# Altium schematic sheet board.SchDoc
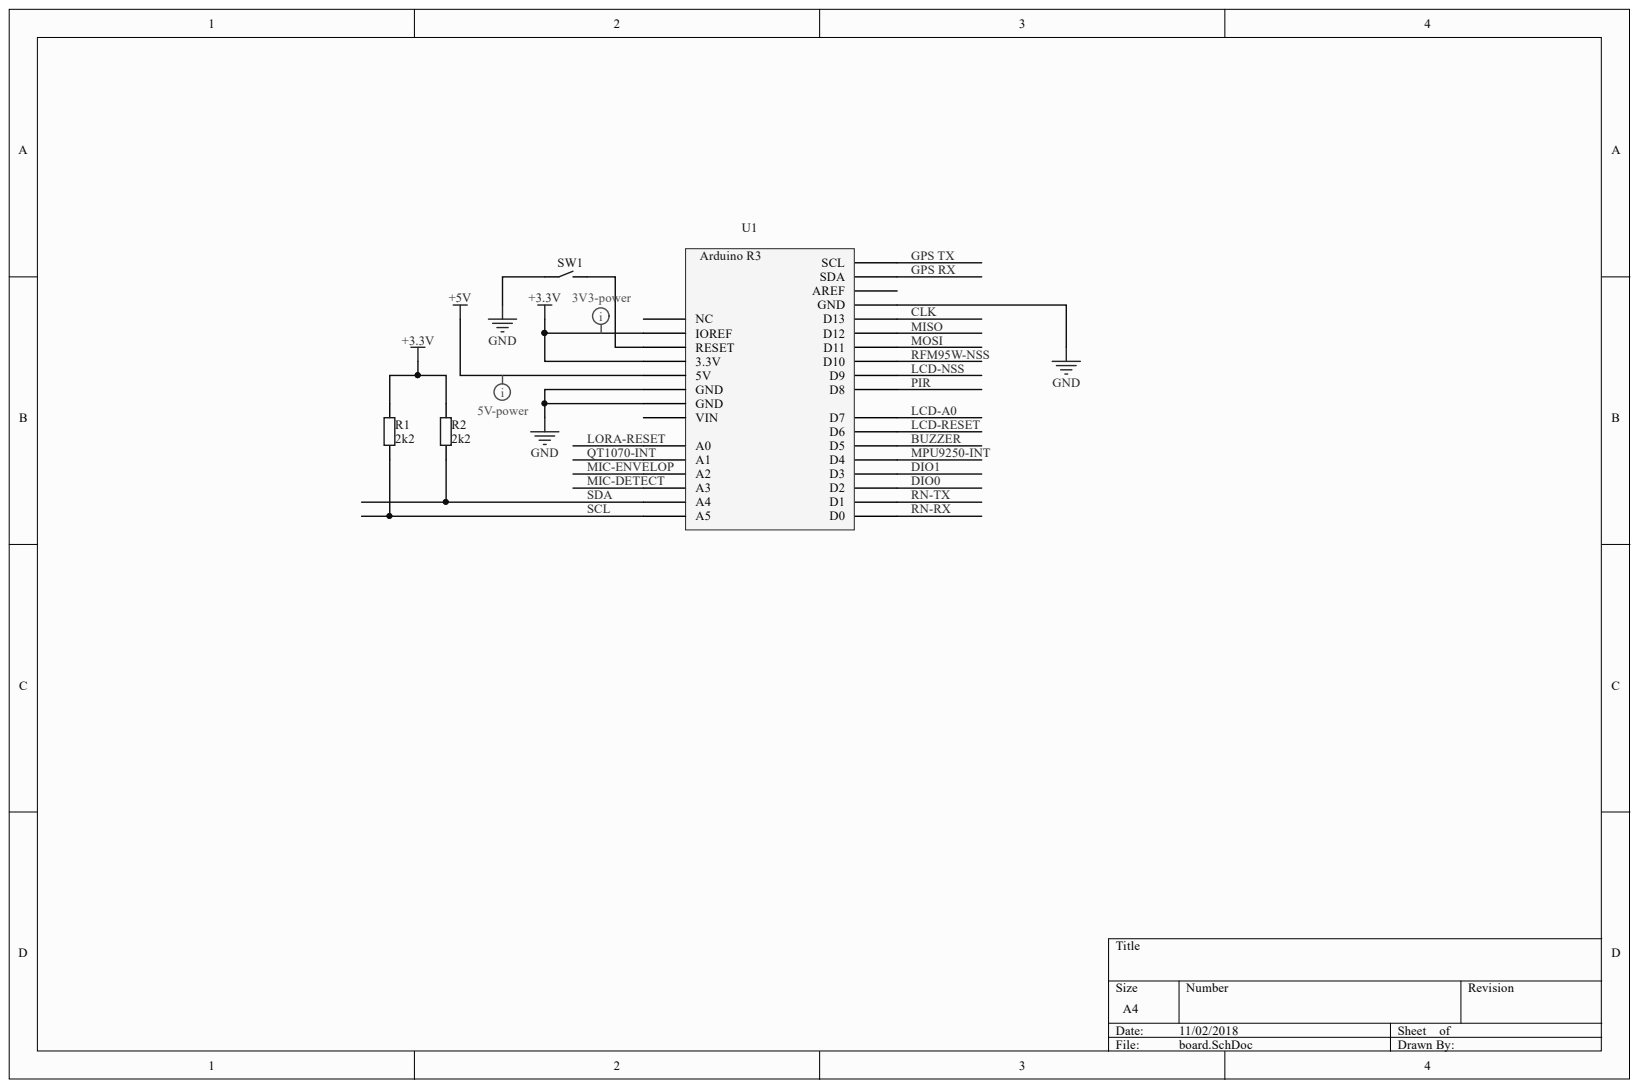
[diagram p0: board.SchDoc]

## Parts
R1  2k2  [PCB-5j234q72qzomlimn5nxa-1]
R2  2k2  [PCB-5j234q72qzomlimn5nxa-1]
SW1  Multicomp DTSM-32S-B  [PCB-ris1royc9wg8soeo1qsf-1]  (value not drawn)
U1  Adafruit Industries 85  [PCB-qf24wffkiumvw1y2m4ko-1]  (value not drawn)

## Nets
+3.3V: R1.2 R2.1 U1.3.3V U1.IOREF
+5V: U1.5V
GND: SW1.1 U1.GND
BUZZER: U1.D5
CLK: U1.D13
DIO0: U1.D2
DIO1: U1.D3
GPS RX: U1.SDA
GPS TX: U1.SCL
LCD-A0: U1.D7
LCD-NSS: U1.D9
LCD-RESET: U1.D6
LORA-RESET: U1.A0
MIC-DETECT: U1.A3
MIC-ENVELOP: U1.A2
MISO: U1.D12
MOSI: U1.D11
MPU9250-INT: U1.D4
PIR: U1.D8
QT1070-INT: U1.A1
RFM95W-NSS: U1.D10
RN-RX: U1.D0
RN-TX: U1.D1
SCL: R1.1 U1.A5
SDA: R2.2 U1.A4
NetSW1_2: SW1.2 U1.RESET

Not connected: U1 AREF NC VIN

## Library data of the parts
R1 R2 = CMP-6e67a46e1d62b1a0-1: Surface Mount Thick Film Resistor, MCWR Series, 2.2 kohm, 100 mW, - 1%, 50 V; Manufacturer Part Number: MCWR06X2201FTL; Power Rating: 100 mW; Case/Package: 0603, 1608; Component Kind: Standard; Library Name: CommunityVault; OctopartID: 6e67a46e1d62b1a0; REACH SVHC Compliance: No SVHC; Resistance Tolerance: ±1%; RoHS: Compliant
SW1 = CMP-86404f18d99f5987-1: Tactile Switch; Series: DTS; Circuitry: SPST-NO; Contact Voltage DC Max: 12V; Contact Current DC Max: 50mA; Switch Te...; Component Kind: Standard; Library Name: CommunityVault; Octopart URL: http://octopart.com/dtsm-32s-b-multicomp-5405369; RoHS: Compliant; Size-Height: 5.00 mm; Size-Length: 6.00 mm
U1 = CMP-6c1fa4a6ab6db3d8-13: arduino header; Manufacturer Part Number: 85; Current Rating: 40.0 mA; Lead-Free Status: Lead Free; Supply Voltage (DC): 28.0 V; Component Kind: Standard; Library Name: CommunityVault; Mounting Style: Snap-In; OctopartID: 6c1fa4a6ab6db3d8; Octopart URL: http://octopart.com/85-adafruit+industries-28566036; RoHS: Compliant; Size-Diameter: 5.80 mm; Size-Length: 20.3 mm; Voltage Rating (DC): 28.0 V
